# Altium schematic sheet AFE_to_ADC_to_ESP.SchDoc(AFE_to_ADC_to_ESP)
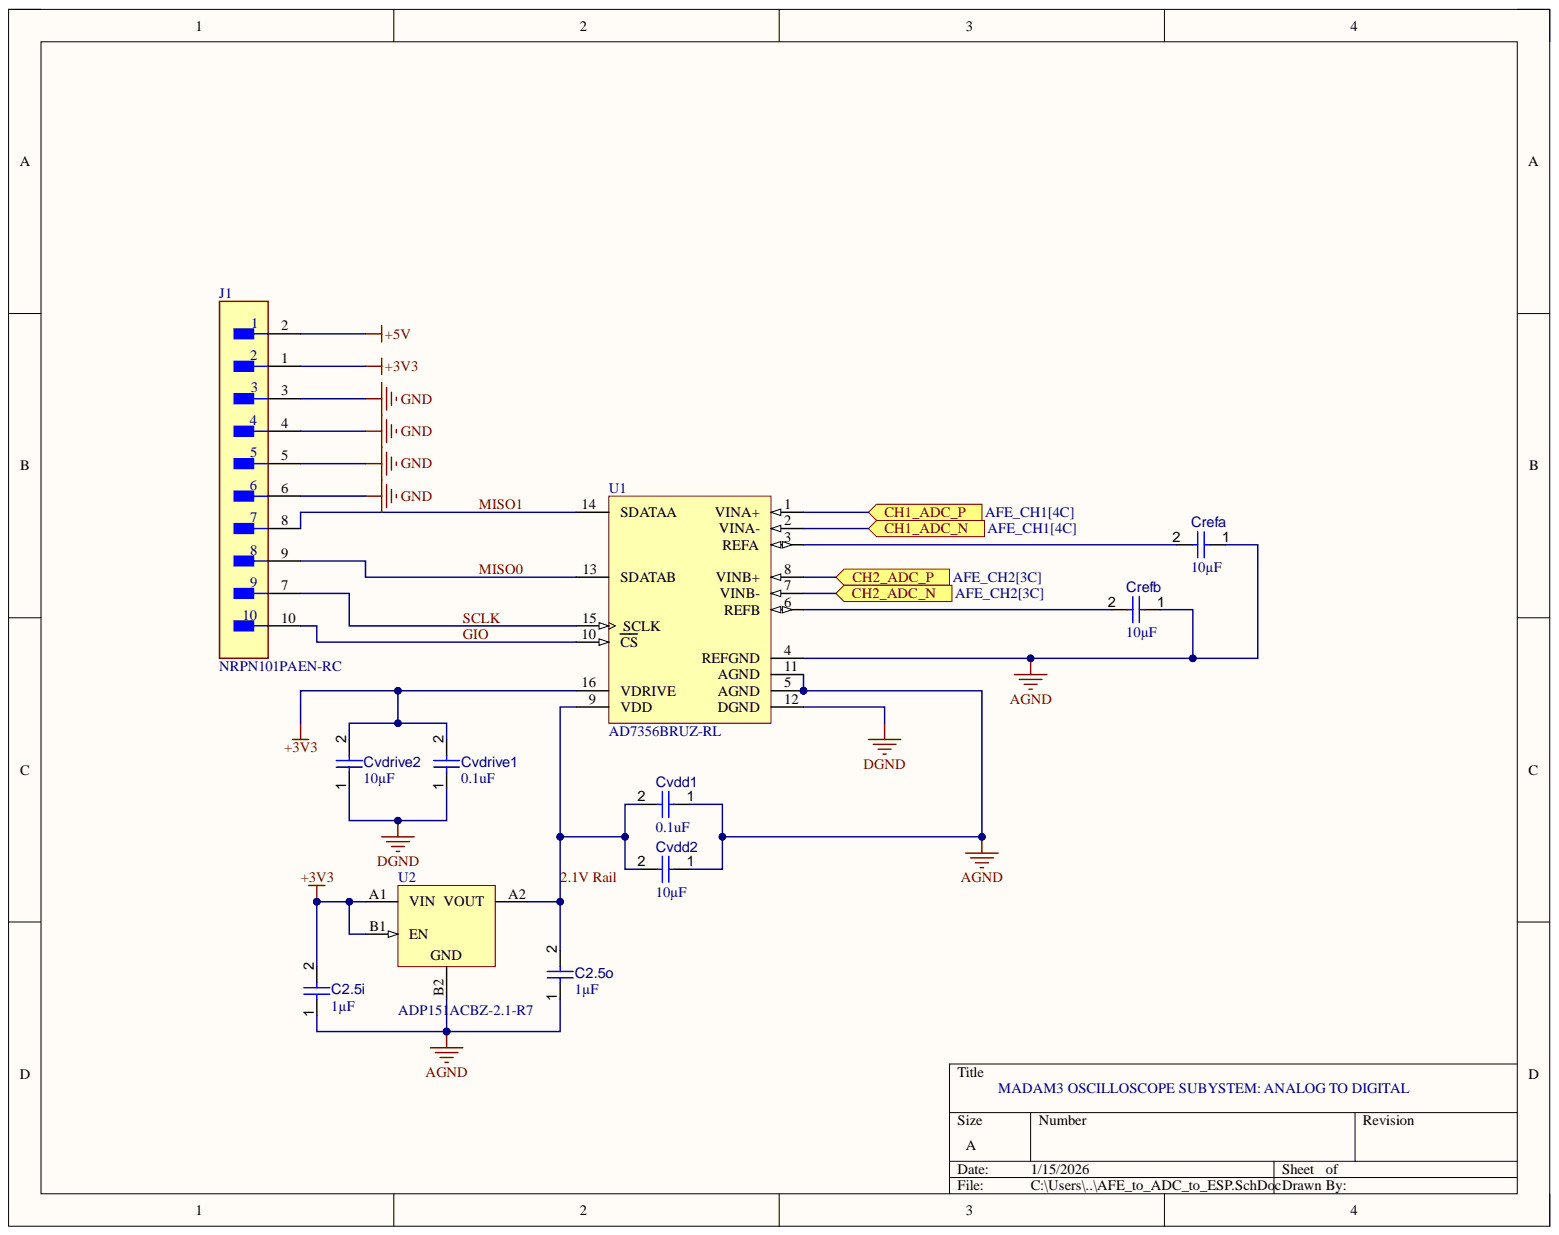
[diagram p0: AFE_to_ADC_to_ESP.SchDoc]

## Parts
C2.5i  value 1µF  [CAPC1608X94X35NL15T15]  (hidden comment: Capacitor 1 uF +/- 10% 16 V 0603)
C2.5o  value 1µF  [CAPC1608X94X35NL15T15]  (hidden comment: Capacitor 1 uF +/- 10% 16 V 0603)
Crefa  value 10µF  [CAPC2013X135X45NL10T25]  (hidden comment: Capacitor 10 uF +/- 10% 16 V 0805)
Crefb  value 10µF  [CAPC2013X135X45NL10T25]  (hidden comment: Capacitor 10 uF +/- 10% 16 V 0805)
Cvdd1  value 0.1uF  [CAPC1608X90X40NL10T20]  (hidden comment: Capacitor 100 nF +/-10% 50 V 0603)
Cvdd2  value 10µF  [CAPC2013X135X45NL10T25]  (hidden comment: Capacitor 10 uF +/- 10% 16 V 0805)
Cvdrive1  value 0.1uF  [CAPC1608X90X40NL10T20]  (hidden comment: Capacitor 100 nF +/-10% 50 V 0603)
Cvdrive2  value 10µF  [CAPC2013X135X45NL10T25]  (hidden comment: Capacitor 10 uF +/- 10% 16 V 0805)
J1  NRPN101PAEN-RC  [FP-NRPN101PAEN-RC-MFG]
U1  AD7356BRUZ-RL  [RU-16_N]
U2  ADP151ACBZ-2.1-R7  [ADI-CB-4-3]

## Ports
CH1_ADC_N, CH1_ADC_P, CH2_ADC_N, CH2_ADC_P

## Nets
+3V3: C2.5i.2 Cvdrive1.2 Cvdrive2.2 J1.1 U1.16(VDRIVE) U2.A1(VIN) U2.B1(EN)
+5V: J1.2
AGND: C2.5i.1 C2.5o.1 Crefa.1 Crefb.1 Cvdd1.1 Cvdd2.1 U1.4(REFGND) U1.5(AGND) U1.11(AGND) U2.B2
DGND: Cvdrive1.1 Cvdrive2.1 U1.12(DGND)
GND: J1.3 J1.4 J1.5 J1.6
2.1V Rail: C2.5o.2 Cvdd1.2 Cvdd2.2 U1.9(VDD) U2.A2(VOUT)
CH1_ADC_N: U1.2(VINA-) ; port CH1_ADC_N
CH1_ADC_P: U1.1(VINA+) ; port CH1_ADC_P
CH2_ADC_N: U1.7(VINB-) ; port CH2_ADC_N
CH2_ADC_P: U1.8(VINB+) ; port CH2_ADC_P
GIO: J1.10 U1.10(CS)
MISO0: J1.9 U1.13(SDATAB)
MISO1: J1.8 U1.14(SDATAA)
SCLK: J1.7 U1.15(SCLK)
NetCrefa_2: Crefa.2 U1.3(REFA)
NetCrefb_2: Crefb.2 U1.6(REFB)

## Library data of the parts
C2.5i C2.5o = CMP-001-00003-6: Chip Capacitor, 1 uF, +/- 10%, 16 V, 0603 (1608 Metric); Capacitor Type: Ceramic; Voltage Rating: 16V; Case/Package: 0603; Component Kind: Standard; Max Operating Temperature: 125°C; Min Operating Temperature: -55°C; Mounting Technology: SM; Pins: 2; RoHS Compliant: True; Tolerance: 10%; Library Name: Amz Amz
Crefa Crefb Cvdd2 Cvdrive2 = CMP-001-00015-6: Chip Capacitor, 10 uF, +/- 10%, 16 V, 0805 (2012 Metric); Capacitor Type: Ceramic; Voltage Rating: 16V; Case/Package: 0805; Component Kind: Standard; Max Operating Temperature: 85°C; Min Operating Temperature: -55°C; Mounting Technology: SM; Pins: 2; RoHS Compliant: True; Tolerance: 10%; Library Name: Amz Amz
Cvdd1 Cvdrive1 = CMP-001-00017-6: Chip Capacitor, 100 nF, +/- 10%, 50 V, 0603 (1608 Metric); Capacitor Type: Ceramic; Voltage Rating: 50V; Case/Package: 0603; Component Kind: Standard; Max Operating Temperature: 125°C; Min Operating Temperature: -55°C; Mounting Technology: SM; Pins: 2; RoHS Compliant: True; Tolerance: 10%; Library Name: Amz Amz
J1 = CMP-25680-000006-1: CONN HEADER VERT 10POS 2MM; Manufacturer: Sullins; Conn Gender: Male; Conn Orientation: Straight; Current Rating: 2A; Number Of Contacts: 10; Voltage Rating: 150V; Automotive: No; Category: Conn; Component Kind: Standard; ComponentLink2Description: Datasheet; ComponentLink2URL: https://s3.amazonaws.com/catalogspreads-pdf/PAGE104-105%202mm%20MALE%20HDR%20ST%20RA%20SMT.pdf; Contact Material: Gold,Nickel; Device Class L1: Connectors; Device Class L2: Headers and Wire Housings; Device Class L3: unset; Digikey Description: CONN HEADER VERT 10POS 2MM; Footprint Url: https://drawings-pdf.s3.amazonaws.com/10531.pdf; Height: 5.95mm; Lead Free: Yes; Library Name: Altium Content Vault; Manufacturer Part Number: NRPN101PAEN-RC; Number Of Rows: 1; Octopart: https://octopart.com/nrpn101paen-rc-sullins-18674398; Package: 0; Pitch: 2mm; RoHS: TRUE; Temperature: -40°C to +105°C; Temperature Range High: +105°C; Temperature Range Low: -40°C
U1 = CMP-0313-01897-2: Dual 12-Bit SAR Analog-to-Digital Converter with Serial, SPI Interface, Simultaneous Sampling, 5MSPS, -40 to +85 degC, RU-16, Pb-Free, Reel; Generic Part Number: AD7356; Manufacturer: Analog Devices; Channels: 2; Interface: Ser, SPI; Resolution (Bits): 12; Throughput Rate (SPS): 5M; Code_JEDEC: MO-153-AB; Component Kind: Standard; ComponentLink1Description: Manufacturer URL; ComponentLink1URL: http://www.analog.com/en/index.html; ComponentLink2Description: Datasheet; ComponentLink2URL: http://www.analog.com/static/imported-files/data_sheets/AD7356.pdf; ComponentLink3Description: Package Specification; ComponentLink3URL: http://www.analog.com/static/imported-files/packages/36244347913743854010197452789RU16.pdf; ComponentLink4Description: Product URL; ComponentLink4URL: http://www.analog.com/en/analog-to-digital-converters/ad-converters/ad7356/products/product.html; DatasheetVersion: Rev.A; Library Name: Altium Content Vault; Mounting Technology: Surface Mount; PackageDescription: 16-Lead Thin Shrink Small Outline Package [TSSOP]; PackageReference: RU-16; PackageVersion: Nov-2002; Packing: Reel; PartNumber: AD7356BRUZ-RL; RoHS: Y
U2 = CMP-0786-00018-1: Ultralow Noise, 200 mA, CMOS Linear Regulator, 2.2 to 5.5 V Vin, 2.1 V Vout, Industrial, 4-pin BGA (CB-4-3), Reel; Manufacturer: Analog Devices; Iout max: 200mA; Preset Vout Values (V): 2.1; Supply Current IGND @ Small Load: 10uA; Vin (max) (V): 5.5; Vin (min) (V): 2.2; Component Kind: Standard; ComponentLink1Description: Manufacturer URL; ComponentLink1URL: http://www.analog.com/en/index.html; ComponentLink2Description: Datasheet; ComponentLink2URL: http://www.analog.com/static/imported-files/data_sheets/ADP151.pdf; ComponentLink3Description: Package Specification; ComponentLink3URL: http:/www.analog.com/static/imported-files/packages/PKG_PDF/WLCSP(CB)/CB_4_3.pdf; ComponentLink4Description: URL Link1; ComponentLink4URL: Product URL:http://www.analog.com/en/ADP151/productSearch.html; DatasheetVersion: Rev. E; Library Name: Altium Content Vault; Mounting Technology: Surface Mount; PackageDescription: 4-Ball Wafer Level Chip Scale Package, Body 0.76 x 0.76 mm, Pitch 0.4 mm; PackageReference: CB-4-3; PackageVersion: 011409-A, 01/2009; Packing: Reel; PartNumber: ADP151ACBZ-2.1-R7
